# Altium schematic sheet CONNECTER.SchDoc
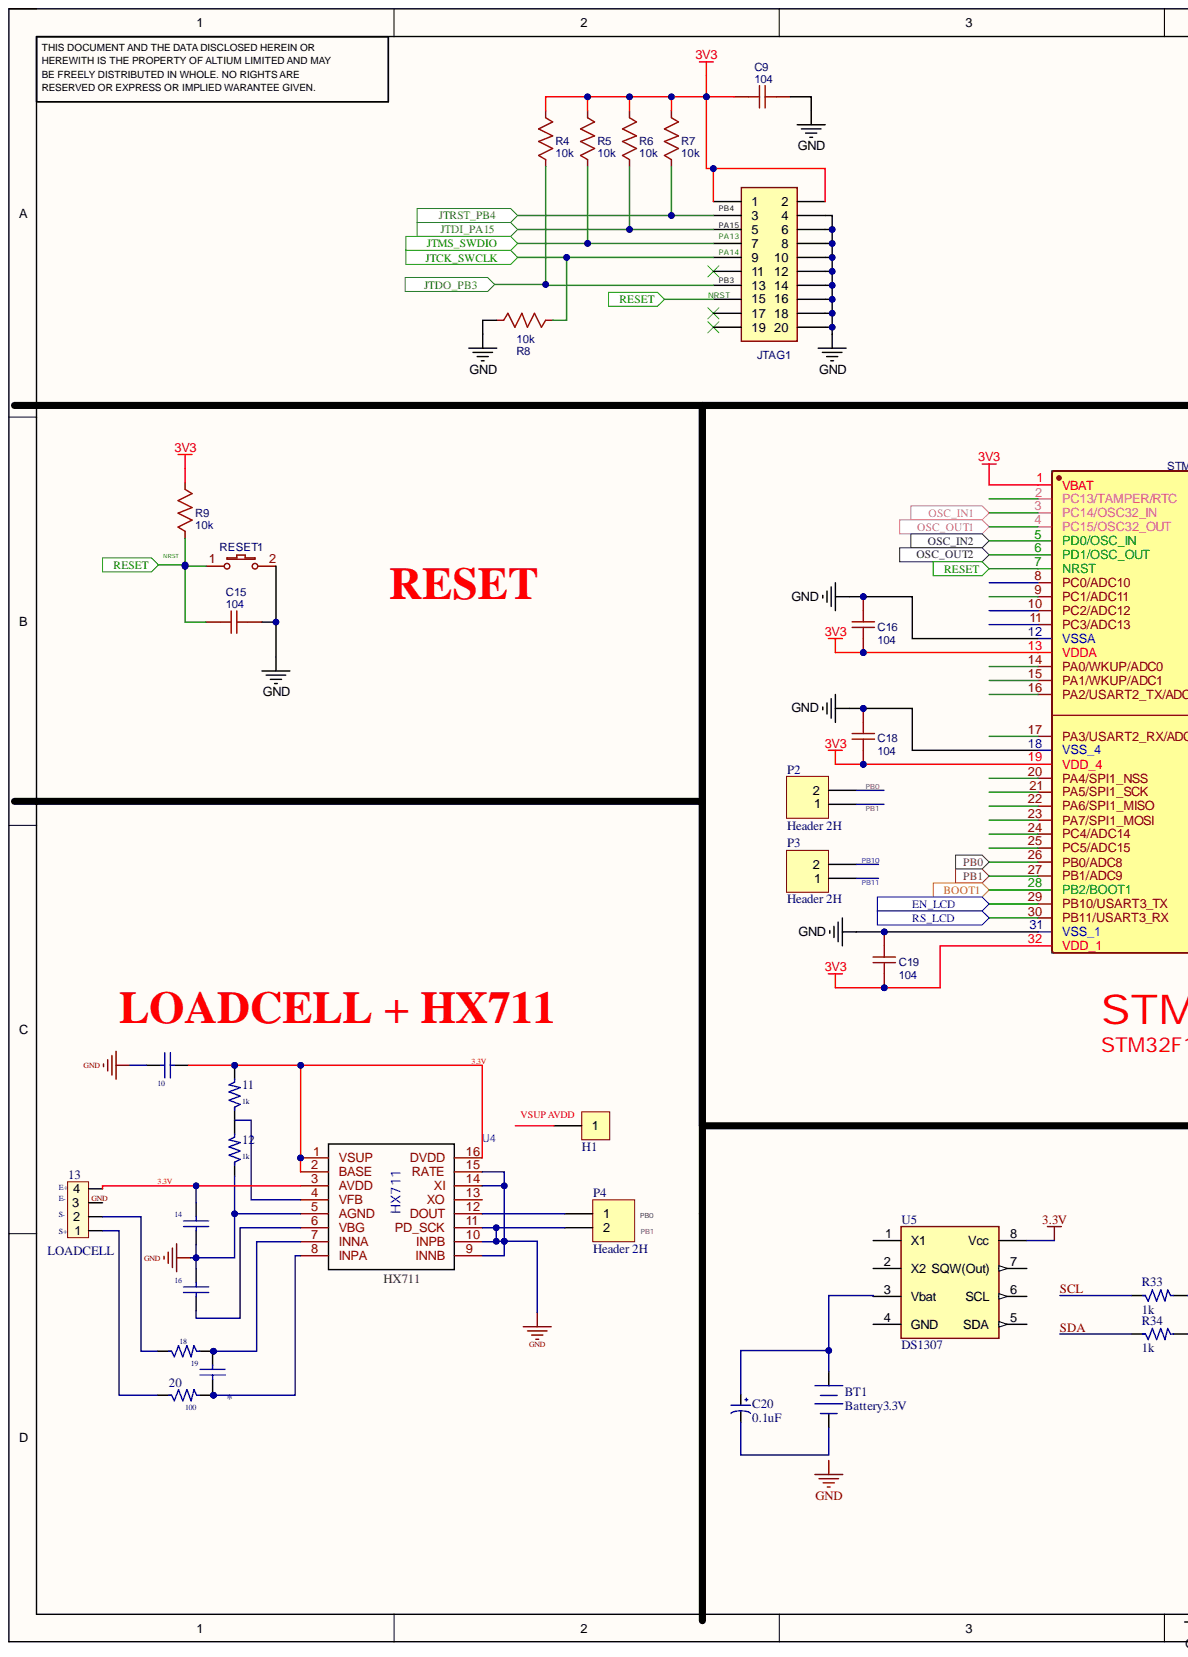
[diagram p0: CONNECTER.SchDoc, left]
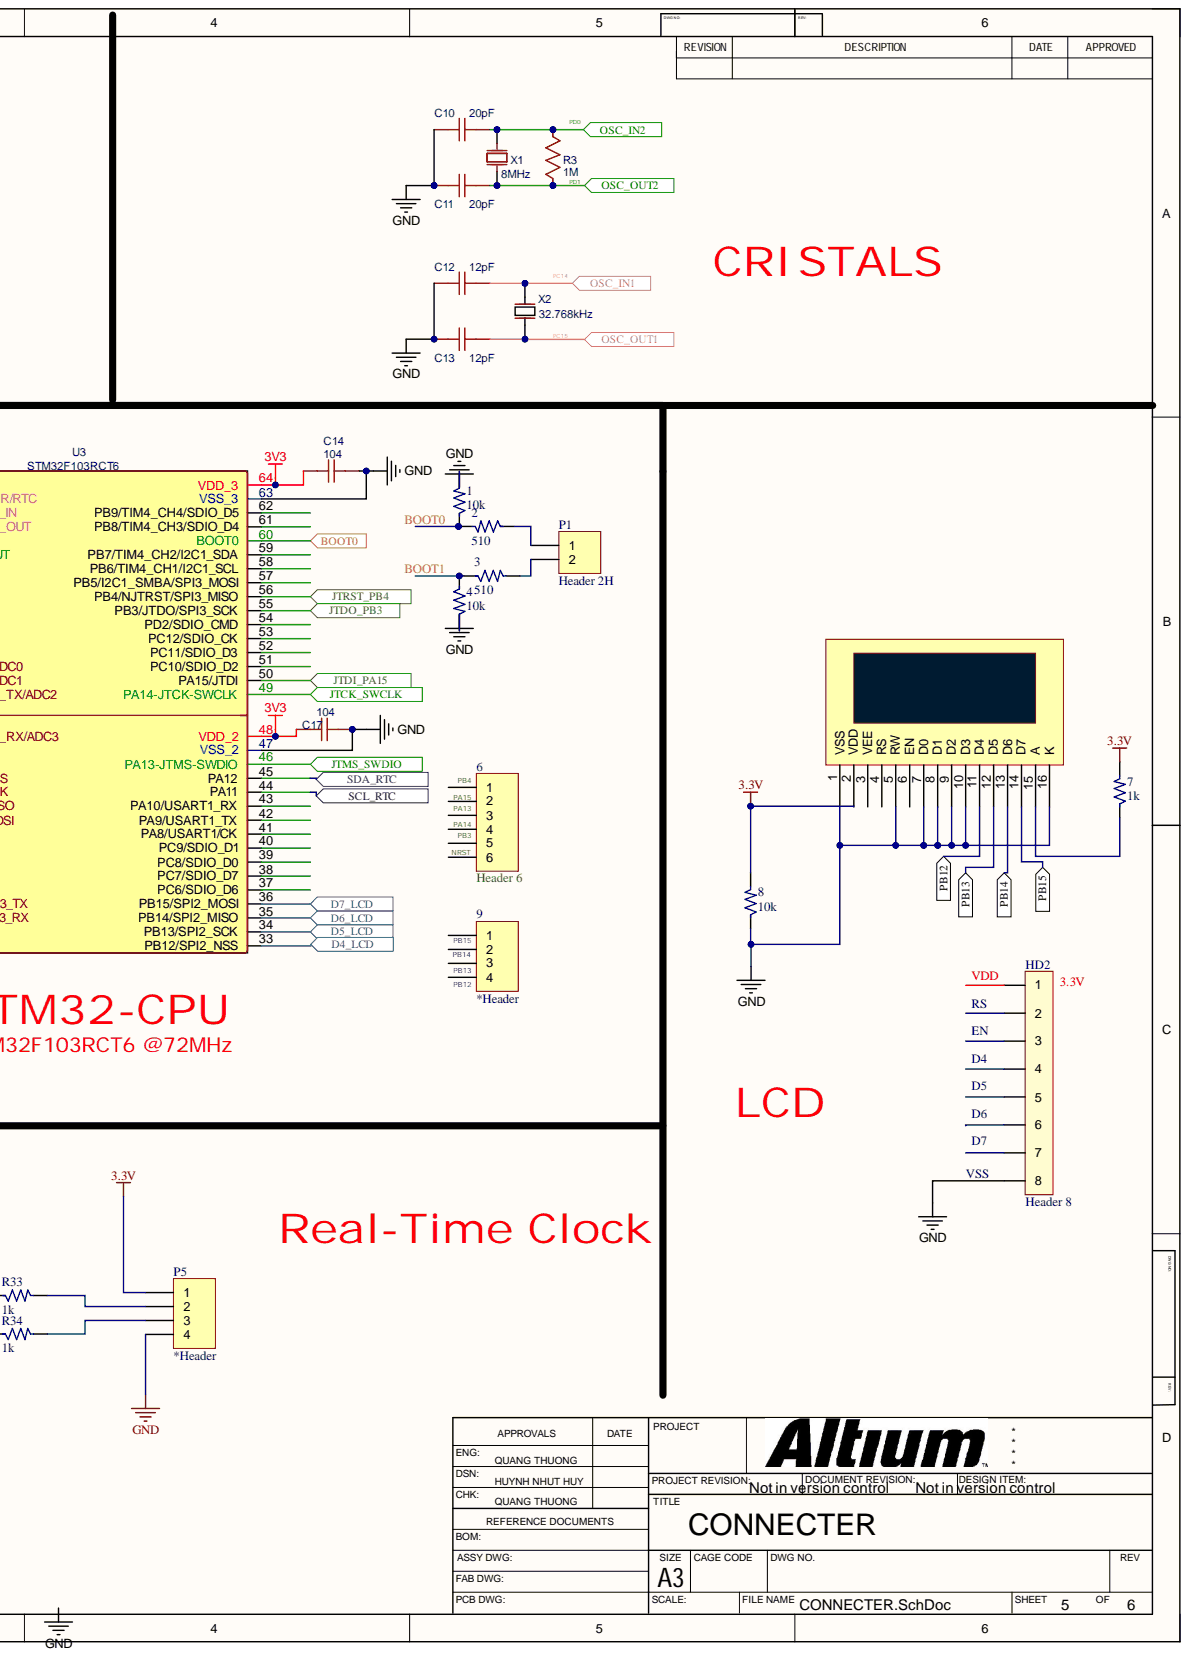
[diagram p1: CONNECTER.SchDoc, right]

## Parts
1  10k  [R1/6W]
2  510  [R1/6W]
3  510  [R1/6W]
4  10k  [R1/6W]
6  Header 6  [HDR1X6]
7  1k  [R1/6W]
8  10k  [R1/6W]
9  *Header  [HDR1X4]
10  (no value)
11  1k  [R1/6W]
12  1k  [R1/6W]
13  LOADCELL  [JP4]
14  (no value)
16  (no value)
18  100  [R1/6W]  (value not drawn)
19  (no value)
20  (no value)  [R1/6W]
BT1  Battery3.3V  [CR2032 Holder]
C9  104  [C0603]
C10  20pF  [C0603]
C11  20pF  [C0603]
C12  12pF  [C0603]
C13  12pF  [C0603]
C14  104  [C0603]
C15  104  [C0603]
C16  104  [C0603]
C17  104  [C0603]
C18  104  [C0603]
C19  104  [C0603]
C20  0.1uF  [CP1/2]
H1  Header 1  [HEAD1]  (value not drawn)
HD2  Header 8  [HED8V]
JTAG1  (no value)  [JTAG]
P1  Header 2H  [HDR1X2H]
P2  Header 2H  [HDR1X2H]
P3  Header 2H  [HDR1X2H]
P4  Header 2H  [HDR1X2H]
P5  *Header  [HDR1X4]
R3  1M  [R0603]
R4  10k  [R0603]
R5  10k  [R0603]
R6  10k  [R0603]
R7  10k  [R0603]
R8  10k  [R0603]
R9  10k  [R0603]
R33  1k  [R1/6W]
R34  1k  [R1/6W]
RESET1  K2-3.6×6.1_SMD  [KEY-SMD_L6.0-W3.5-LS8.0]  (value not drawn)
U3  STM32F103RCT6  [STM32F103RCT6]
U4  (no value)  [SOP-16_L10.0-W3.9-P1.27-LS6.0-BL]
U5  DS1307  [DIP.100/8/W.300/L.400]
X1  8MHz  [HC-49SMD]
X2  32.768kHz  [CRYSTAL-TH_BD3.1-L8.0-P2.00-1]
  LCD  [LCD16X2]  (value not drawn)

## Ports
BOOT0, BOOT1, D4_LCD, D5_LCD, D6_LCD, D7_LCD, EN_LCD, JTCK_SWCLK, JTDI_PA15, JTDO_PB3, JTMS_SWDIO, JTRST_PB4, OSC_IN1, OSC_IN2, OSC_OUT1, OSC_OUT2, PB0, PB1, PB12, PB13, PB14, PB15, RESET, RS_LCD, SCL_RTC, SDA_RTC

## Nets
3.3V: 7.2 8.2 P5.1 U5.8(Vcc) .2(VDD)
3V3: C9.1 C14.1 C16.1 C17.1 C18.1 C19.1 JTAG1.1 JTAG1.2 R4.2 R5.2 R6.2 R7.2 R9.2 U3.1(VBAT) U3.13(VDDA) U3.19(VDD_4) U3.32(VDD_1) U3.48(VDD_2) U3.64(VDD_3)
GND: 1.2 4.2 8.1 10.1 12.1 14.2 16.1 C9.2 C10.1 C11.1 C12.1 C13.1 C14.2 C15.2 C16.2 C17.2 C18.2 C19.2 HD2.8 JTAG1.4 JTAG1.6 JTAG1.8 JTAG1.10 JTAG1.12 JTAG1.14 JTAG1.16 JTAG1.18 JTAG1.20 P4.2 P5.4 R8.1 RESET1.2 U3.12(VSSA) U3.18(VSS_4) U3.31(VSS_1) U3.47(VSS_2) U3.63(VSS_3) U4.5(AGND) U4.9(INNB) U4.10(INPB) U4.11(PD_SCK) U4.14(XI) U4.15(RATE) .1(VSS) .5(RW) .7(D0) .8(D1) .9(D2) .10(D3) .16(K)
Net_3: .3(VEE)
Net_4: .4(RS)
Net_6: .6(EN)
BOOT0: U3.60(BOOT0) ; port BOOT0
BOOT1: U3.28(PB2/BOOT1) ; port BOOT1
D4_LCD: U3.33(PB12/SPI2_NSS) ; port D4_LCD
D5_LCD: U3.34(PB13/SPI2_SCK) ; port D5_LCD
D6_LCD: U3.35(PB14/SPI2_MISO) ; port D6_LCD
D7_LCD: U3.36(PB15/SPI2_MOSI) ; port D7_LCD
EN_LCD: U3.29(PB10/USART3_TX) ; port EN_LCD
JTCK_SWCLK: JTAG1.9 R8.2 U3.49(PA14-JTCK-SWCLK) ; port JTCK_SWCLK
JTDI_PA15: JTAG1.5 R6.1 U3.50(PA15/JTDI) ; port JTDI_PA15
JTDO_PB3: JTAG1.13 R4.1 U3.55(PB3/JTDO/SPI3_SCK) ; port JTDO_PB3
JTMS_SWDIO: JTAG1.7 R5.1 U3.46(PA13-JTMS-SWDIO) ; port JTMS_SWDIO
JTRST_PB4: JTAG1.3 R7.1 U3.56(PB4/NJTRST/SPI3_MISO) ; port JTRST_PB4
Net_11: .11(D4) ; port PB12
Net_12: .12(D5) ; port PB13
Net_13: .13(D6) ; port PB14
Net_14: .14(D7) ; port PB15
OSC_IN1: C12.2 U3.3(PC14/OSC32_IN) X2.2 ; port OSC_IN1
OSC_IN2: C10.2 R3.2 U3.5(PD0/OSC_IN) X1.1 ; port OSC_IN2
OSC_OUT1: C13.2 U3.4(PC15/OSC32_OUT) X2.1 ; port OSC_OUT1
OSC_OUT2: C11.2 R3.1 U3.6(PD1/OSC_OUT) X1.2 ; port OSC_OUT2
PB0: U3.26(PB0/ADC8) ; port PB0
PB1: U3.27(PB1/ADC9) ; port PB1
RESET: C15.1 JTAG1.15 R9.1 RESET1.1 U3.7(NRST) ; port RESET
RS_LCD: U3.30(PB11/USART3_RX) ; port RS_LCD
SCL: R33.1
SCL_RTC: U3.44(PA11) ; port SCL_RTC
SDA: R34.1
SDA_RTC: U3.45(PA12) ; port SDA_RTC
Net1_1: 1.1 2.2
Net2_1: 2.1 P1.1
Net3_1: 3.1 4.1
Net3_2: 3.2 P1.2
Net7_1: 7.1 .15(A)
Net10_2: 10.2 11.2 U4.1(VSUP) U4.2(BASE) U4.16(DVDD)
Net12_2: 12.2 U4.4(VFB)
Net13_1: 13.1 20.1
Net13_2: 13.2 18.1
Net13_4: 13.4 14.1 U4.3(AVDD)
Net16_2: 16.2 U4.6(VBG)
Net18_2: 18.2 19.1 U4.7(INNA)
Net19_2: 19.2 20.2 U4.8(INPA)
NetBT1_1: BT1.1 C20.1 U5.3(Vbat)
NetBT1_2: BT1.2 C20.2
NetP4_1: P4.1 U4.12(DOUT)
NetP5_2: P5.2 R33.2
NetP5_3: P5.3 R34.2

Not connected: 6 1 2 3 4 5 6; 9 1 2 3 4; 11 1; 13 3; H1 1; HD2 1 2 3 4 5 6 7; JTAG1 11 17 19; P2 1 2; P3 1 2; U3 2(PC13/TAMPER/RTC) 8(PC0/ADC10) 9(PC1/ADC11) 10(PC2/ADC12) 11(PC3/ADC13) 14(PA0/WKUP/ADC0) 15(PA1/WKUP/ADC1) 16(PA2/USART2_TX/ADC2) 17(PA3/USART2_RX/ADC3) 20(PA4/SPI1_NSS) 21(PA5/SPI1_SCK) 22(PA6/SPI1_MISO) 23(PA7/SPI1_MOSI) 24(PC4/ADC14) 25(PC5/ADC15) 37(PC6/SDIO_D6) 38(PC7/SDIO_D7) 39(PC8/SDIO_D0) 40(PC9/SDIO_D1) 41(PA8/USART1/CK) 42(PA9/USART1_TX) 43(PA10/USART1_RX) 51(PC10/SDIO_D2) 52(PC11/SDIO_D3) 53(PC12/SDIO_CK) 54(PD2/SDIO_CMD) 57(PB5/I2C1_SMBA/SPI3_MOSI) 58(PB6/TIM4_CH1/I2C1_SCL) 59(PB7/TIM4_CH2/I2C1_SDA) 61(PB8/TIM4_CH3/SDIO_D4) 62(PB9/TIM4_CH4/SDIO_D5); U4 13(XO); U5 1(X1) 2(X2) 4(GND) 5(SDA) 6(SCL) 7(SQW(Out))

## Library data of the parts
1-4 7 8 11 12 18 20 R33 R34 = Res: Resistor 1/4W; Value: R1/4W - 1K; Component Kind: Standard; Library Name: Cty1.SchLib
6 = Header 6: Header, 6-Pin; Component Kind: Standard; LatestRevisionDate: 17-Jul-2002; LatestRevisionNote: Re-released for DXP Platform.; Library Name: Cty1.SchLib; Signal Integrity: Connector; Publisher: Altium Limited
9 P5 = Header 4: Header, 4-Pin, Right Angle; Component Kind: Standard; LatestRevisionDate: 17-Jul-2002; LatestRevisionNote: Re-released for DXP Platform.; Library Name: Cty1.SchLib; Signal Integrity: Connector; Publisher: Altium Limited
10 14 16 19 = CAP: Capacitor; Component Kind: Standard; Library Name: Cty1.SchLib
13 = JP4: JP4 PIN; Component Kind: Standard; Library Name: Cty1.SchLib; Value: JP4
BT1 = Battery3.3V: Multicell Battery; Component Kind: Standard; LatestRevisionDate: 17-Jul-2002; LatestRevisionNote: Re-released for DXP Platform.; Library Name: Cty1.SchLib; PackageReference: BAT-2; Published: 24-Mar-1999; Publisher: Altium Limited
C9 C14-C19 = 104: Contributor: LCEDA_Lib; nameAlias: Value(F); Component Kind: Standard; spicePre: C; spiceSymbolName: C_1206_US
C10 C11 = 20pF: BOM_Manufacturer: FH; BOM_Manufacturer Part: 0603CG200J500NT; nameAlias: Capacitance; BOM_Supplier: LCSC; BOM_Supplier Part: C91702; Component Kind: Standard; spicePre: C; spiceSymbolName: 0603CG200J500NT
C12 C13 = 12pF: BOM_Manufacturer: FH; BOM_Manufacturer Part: 0603CG200J500NT; nameAlias: Capacitance; BOM_Supplier: LCSC; BOM_Supplier Part: C91702; Component Kind: Standard; spicePre: C; spiceSymbolName: 0603CG200J500NT
C20 = CP1: Cap P  0,1uF < 22uF , 16 - 50V; Description 1: 10 => 220uF/6,3V/10V - 10uF => 100uF/16V; Description 2: 0,1uF => 33uF/50V - 10uF => 22uF/63V; Description 3: 0,47uF => 10uF/100V; Value: 10uF/50V; Component Kind: Standard; Library Name: Cty1.SchLib
H1 = Header 1: Header, 1-Pin, Right Angle; Revised: Zion Blue; Component Kind: Standard; Library Name: Cty1.SchLib; Published: 6-May-2014; Publisher: Cynetik Group
HD2 = Header 8: Header 8 Vit Broad; Component Kind: Standard; Library Name: Cty1.SchLib
JTAG1 = Header 10X2: Component Kind: Standard; spicePre: J; spiceSymbolName: Header 10X2
P1-P4 = Header 2H: Header, 2-Pin, Right Angle; Component Kind: Standard; LatestRevisionDate: 17-Jul-2002; LatestRevisionNote: Re-released for DXP Platform.; Library Name: Cty1.SchLib; Signal Integrity: Connector; Publisher: Altium Limited
R3 = 1M: Contributor: LCEDA_Lib; nameAlias: Value(Ω); Component Kind: Standard; spicePre: R; spiceSymbolName: R_0805_US
R4-R9 = 10k: Contributor: LCEDA_Lib; nameAlias: Value(Ω); Component Kind: Standard; spicePre: R; spiceSymbolName: R_0805_US
RESET1 = K2-3.6×6.1_SMD: BOM_Manufacturer Part: K2-1107ST-A4SW-06; Contributor: LCEDA_Lib; 制造商: 韩国韩荣; BOM_Supplier: LCSC; BOM_Supplier Part: C118141; Component Kind: Standard; spicePre: K; spiceSymbolName: K2-3.6×6.1_SMD
U3 = STM32F103RCT6: BOM_Manufacturer: ST(意法半导体); Contributor: wegi1; BOM_JLCPCB Part Class: Extended Part; BOM_Manufacturer Part: STM32F103RCT6; BOM_Supplier: LCSC; BOM_Supplier Part: C8318; Component Kind: Standard; spicePre: U; spiceSymbolName: STM32F103RCT6
U4 = HX711: Component Kind: Standard
U5 = DS1307: 64 X 8 Serial Real-Time Clock; Component Kind: Standard; Library Name: Cty1.SchLib
X1 = 8MHz: BOM_Manufacturer: TAE; BOM_Manufacturer Part: HC-49SM8MHz20pF30ppm; Contributor: T. Ruiz; nameAlias: Frequency; BOM_Supplier: LCSC; BOM_Supplier Part: C107426; Component Kind: Standard; spicePre: X; spiceSymbolName: HC-49SM8MHz20pF30ppm
X2 = 32.768kHz: BOM_Manufacturer: HD(浩都频率); BOM_Manufacturer Part: 7Q032768NW2; Contributor: LCSC; nameAlias: Frequency; BOM_JLCPCB Part Class: Extended Part; BOM_Supplier: LCSC; BOM_Supplier Part: C655213; Component Kind: Standard; spicePre: X; spiceSymbolName: 7Q032768NW2
 = LCD: Value: LCD16x2; Component Kind: Standard; Library Name: Cty1.SchLib
